# Altium schematic sheet 扩展板_光强.SchDoc(扩展板_光强)
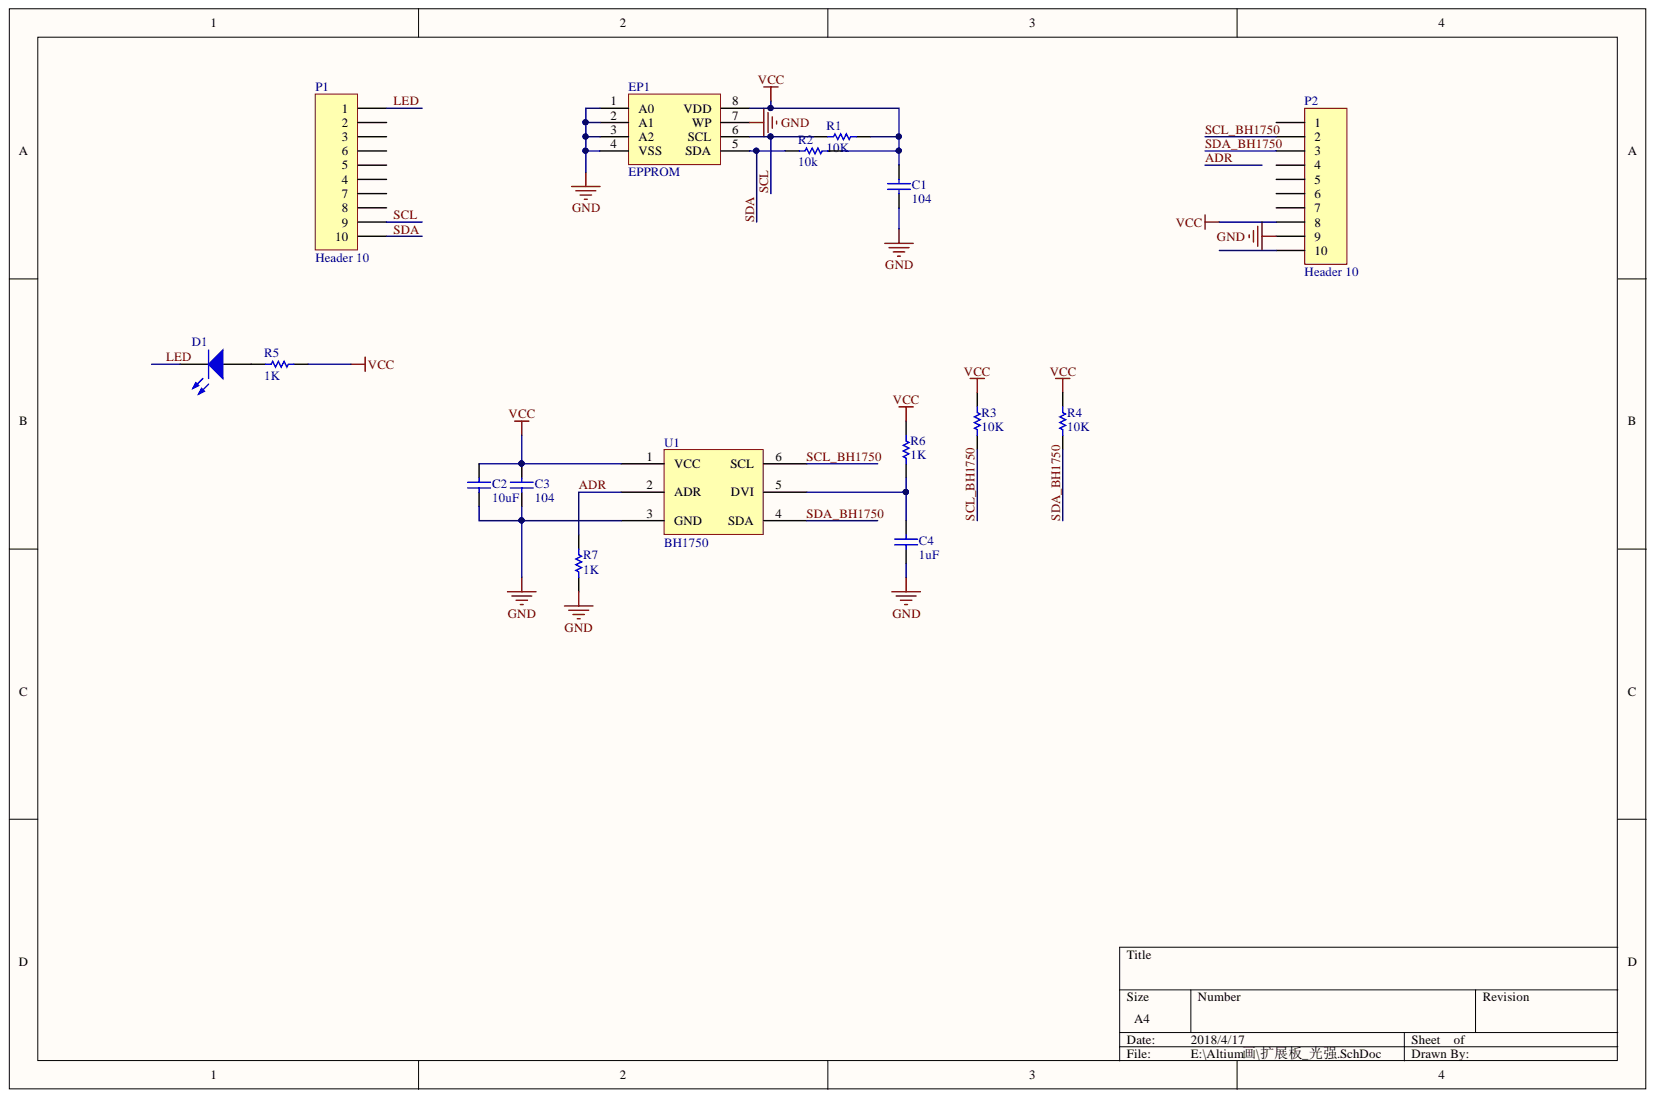
[diagram p0: 扩展板_光强.SchDoc]

## Parts
C1  104  [C0603]
C2  10uF  [C0805]
C3  104  [C0603]
C4  1uF  [C0603]
D1  LED  [LED_0805]
EP1  EPPROM  [SO-8]
P1  Header 10  [HDR1X10]
P2  Header 10  [HDR1X10]
R1  10K  [R0603]
R2  10k  [R0603]
R3  10K  [R0603]
R4  10K  [R0603]
R5  1K  [R0603]
R6  1K  [R0603]
R7  1K  [R0603]
U1  BH1750  [BH1750]

## Nets
GND: C1.1 C2.1 C3.1 C4.1 EP1.1(A0) EP1.2(A1) EP1.3(A2) EP1.4(VSS) EP1.7(WP) P2.9 R7.1 U1.3(GND)
VCC: C1.2 C2.2 C3.2 EP1.8(VDD) P2.8 R1.1 R2.1 R3.2 R4.2 R5.2 R6.2 U1.1(VCC)
ADR: R7.2 U1.2(ADR)
LED: D1.2 P1.1
SCL: EP1.6(SCL) P1.9 R1.2
SCL_BH1750: P2.2 R3.1 U1.6(SCL)
SDA: EP1.5(SDA) P1.10 R2.2
SDA_BH1750: P2.3 R4.1 U1.4(SDA)
NetC4_2: C4.2 R6.1 U1.5(DVI)
NetD1_1: D1.1 R5.1

Not connected: P1 2 3 4 5 6 7 8; P2 1 4 5 6 7 10

## Library data of the parts
C1-C4 = CAP: Capacitor; Library Name: Devices.IntLib; Component Kind: Standard
D1 = LED1: Typical RED GaAs LED; Simulation: LED1; Published: 8-Jun-2000; PackageReference: LED-1; PackageDescription: LED; 2 Leads; Library Name: Devices.IntLib; LatestRevisionNote: Mech Layer 1 removed.; LatestRevisionDate: 08-Jul-2005; Component Kind: Standard; Publisher: Altium Limited
EP1 = EPPROM: ROM: 24C02; Library Name: IC.IntLib; Component Kind: Standard
P1 P2 = Header 10: Header, 10-Pin; Signal Integrity: Connector; Library Name: Connectors.IntLib; LatestRevisionNote: Re-released for DXP Platform.; LatestRevisionDate: 17-Jul-2002; Component Kind: Standard; Publisher: Altium Limited
R1-R4 = Res1: Resistor; Value: 1K; Simulation: RESISTOR; Signal Integrity: Res; Published: 8-Jun-2000; PackageReference: AXIAL-0.3; PackageDescription: Axial Device, Thru-Hole; 2 Leads; 0.3 in Pin Spacing; Library Name: Devices.IntLib; LatestRevisionNote: Re-released for DXP Platform.; LatestRevisionDate: 17-Jul-2002; Component Kind: Standard; Publisher: Altium Limited
R5-R7 = Res1: Resistor; Value: 1K; Simulation: RESISTOR; Signal Integrity: Res; Published: 8-Jun-2000; PackageReference: AXIAL-0.3; PackageDescription: Axial Device, Thru-Hole; 2 Leads; 0.3 in Pin Spacing; Library Name: Devices.IntLib; LatestRevisionNote: Re-released for DXP Platform.; LatestRevisionDate: 17-Jul-2002; Component Kind: Standard; Publisher: Altium Limited
U1 = BH1750: Component Kind: Standard; Library Name: kuozhang.SchLib
